# Altium schematic sheet 3.INPUT_GENSchDoc.SchDoc
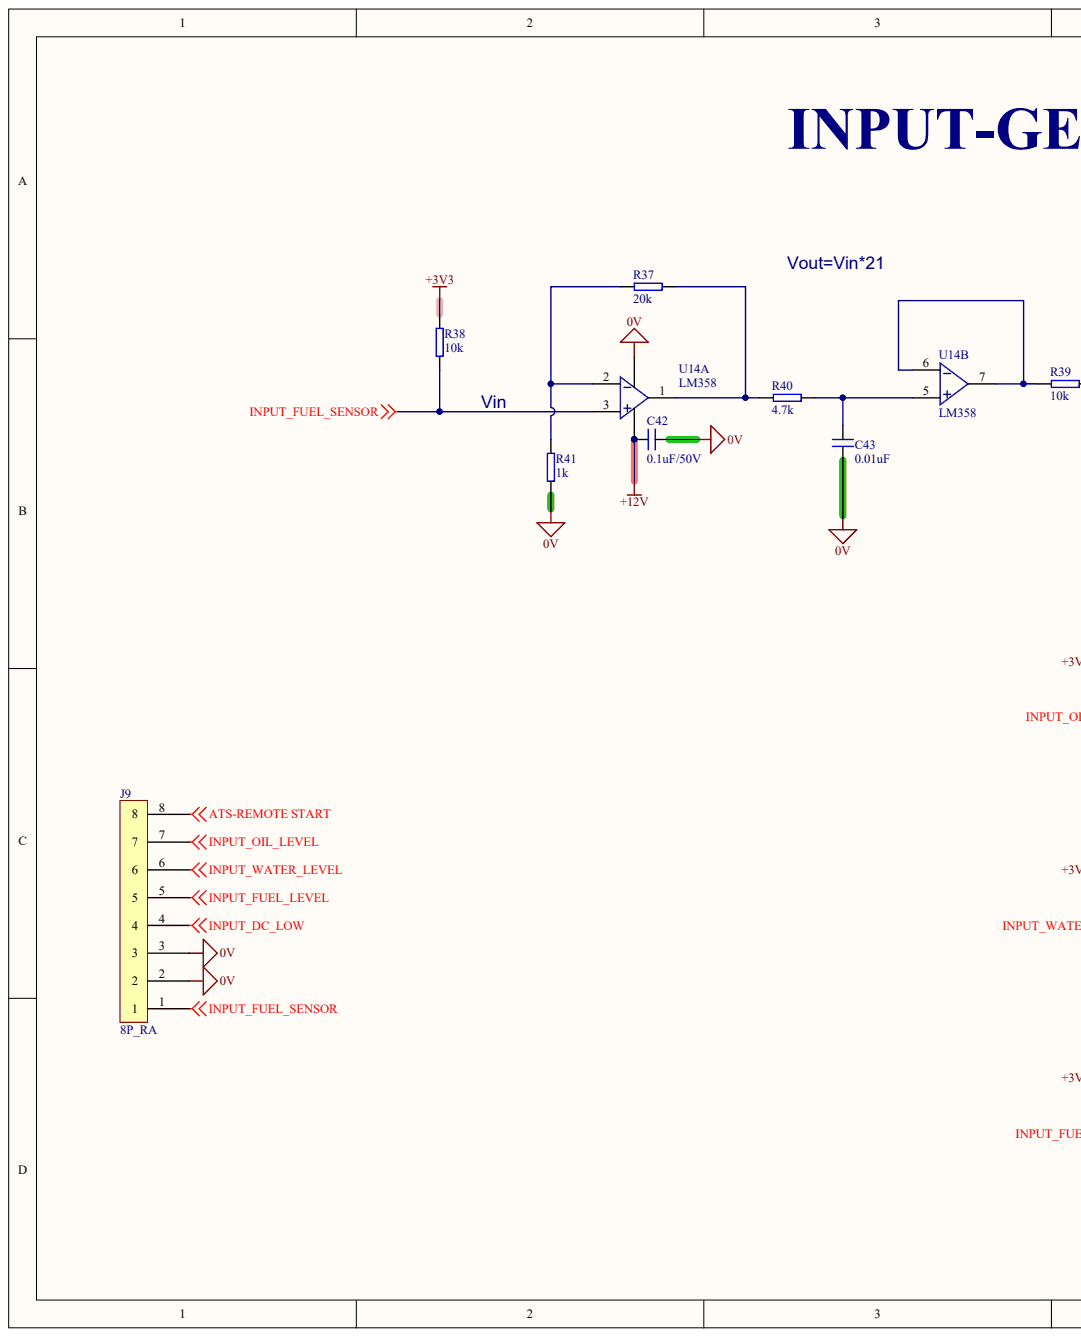
[diagram p0: 3.INPUT_GENSchDoc.SchDoc, left]
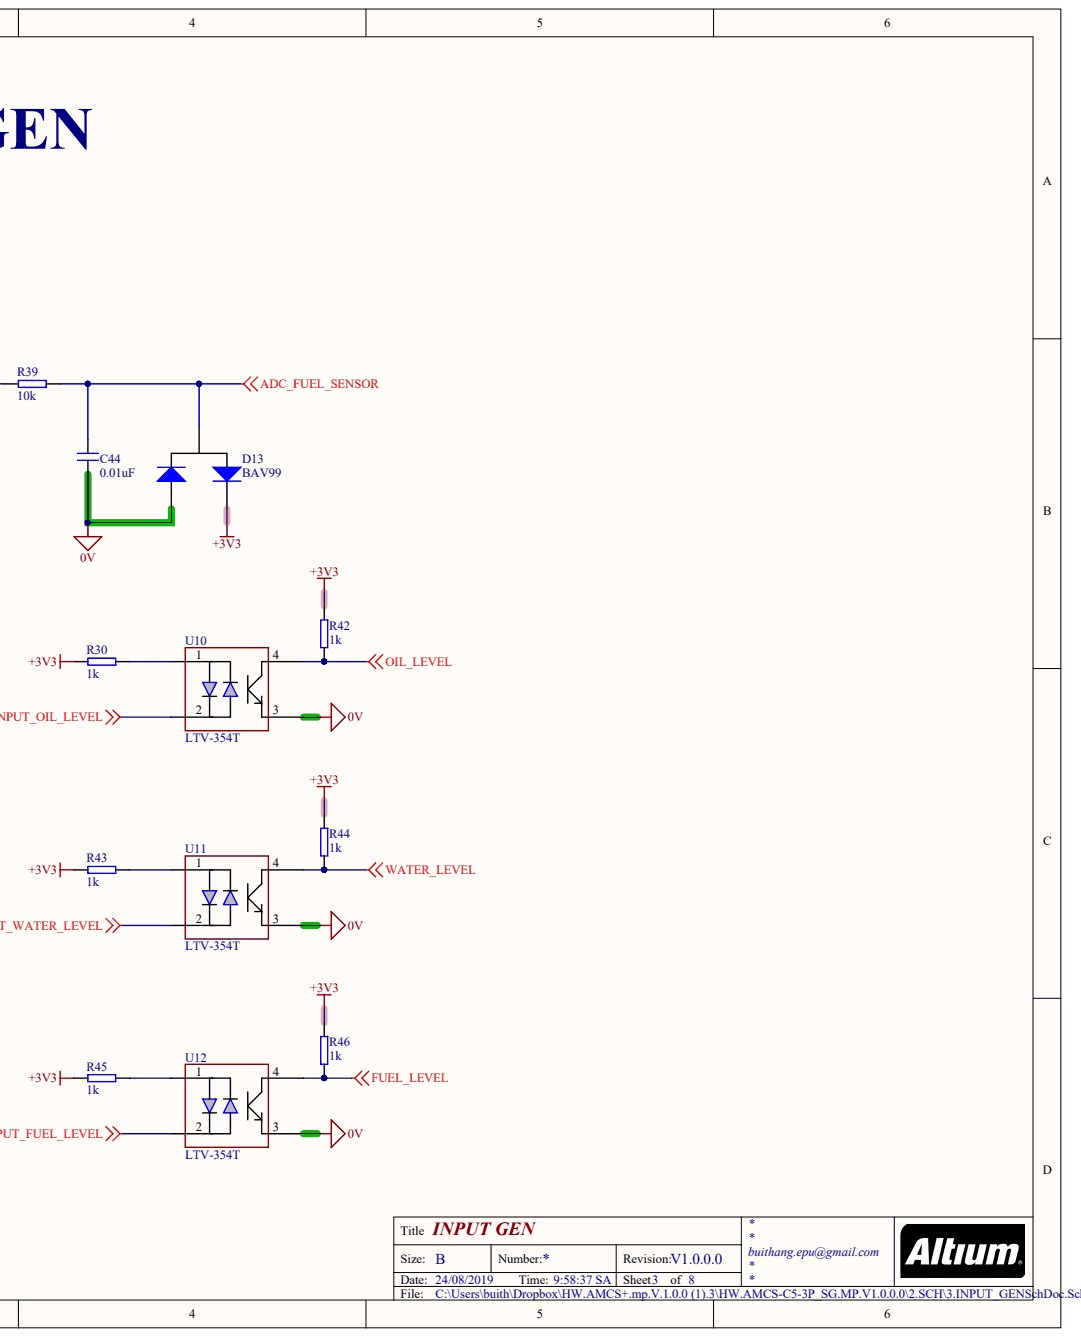
[diagram p1: 3.INPUT_GENSchDoc.SchDoc, right]

## Parts
C42  0.1uF/50V  [0805]
C43  0.01uF  [0805]
C44  0.01uF  [0805]
D13  BAV99  [SOT-23]
J9  8P_RA  [CONN_8x1_3.81MM]
R30  1k  [0805]
R37  20k  [0805]
R38  10k  [0805]
R39  10k  [0805]
R40  4.7k  [0805]
R41  1k  [0805]
R42  1k  [0805]
R43  1k  [0805]
R44  1k  [0805]
R45  1k  [0805]
R46  1k  [0805]
U10  LTV-354T  [SMD-4]
U11  LTV-354T  [SMD-4]
U12  LTV-354T  [SMD-4]
U14  LM358  [SOIC-8_3.9]  (parts A,B)

## Nets
0V: C42.1 C43.1 C44.1 D13.1 J9.2 J9.3 R41.2 U10.3 U11.3 U12.3 U14.4
+3V3: D13.2 R30.2 R38.1 R42.1 R43.2 R44.1 R45.2 R46.1
+12V: C42.2 U14.8
ADC_FUEL_SENSOR: C44.2 D13.3 R39.2
ATS-REMOTE START: J9.8
FUEL_LEVEL: R46.2 U12.4
INPUT_DC_LOW: J9.4
INPUT_FUEL_LEVEL: J9.5 U12.2
INPUT_FUEL_SENSOR: J9.1 R38.2 U14.3
INPUT_OIL_LEVEL: J9.7 U10.2
INPUT_WATER_LEVEL: J9.6 U11.2
OIL_LEVEL: R42.2 U10.4
WATER_LEVEL: R44.2 U11.4
NetC43_2: C43.2 R40.1 U14.5
NetR30_1: R30.1 U10.1
NetR37_1: R37.1 R41.1 U14.2
NetR37_2: R37.2 R40.2 U14.1
NetR39_1: R39.1 U14.6 U14.7
NetR43_1: R43.1 U11.1
NetR45_1: R45.1 U12.1

## Library data of the parts
C42 = Cap_0.1uF: CAP CER 0.1UF 50V Y5V 0805; MFR: Yageo; Part Number: CC0805ZRY5V9BB104; AMCS CODE: VT-CAP-0004; ASS: SMT; Component Kind: Standard; Package: 0805; Library Name: Schlib_Diepvn_V01.SchLib
C43 C44 = Cap_0.01uF: CAP CER 10nF 50V X7R 0805; MFR: Yageo; Part Number: CC0805KRX7R9BB103; AMCS CODE: VT-CAP-0007; Component Kind: Standard; Package: 0805; Library Name: Schlib_Diepvn_V01.SchLib
D13 = DiO BAV99: DIODE ARRAY GP 100V 215MA SOT23; MFR: NXP Semiconductors; Part Number: BAV99,215; AMCS CODE: VT-DIO-0001; Component Kind: Standard; Package: SOT23; Library Name: Schlib_Diepvn_V01.SchLib
J9 = CONN_8x1_3.81: Pitch: 3.81MM, 8 POS 90 DEG; MFR: TE; Part Number: 1-284513-0; Part Number 1: 284513-8; AMCS CODE: VT-CON-0022; ASS: TH; Component Kind: Standard; Package: Pitch: 3.81; TYPE: CONN; Library Name: Schlib_Diepvn_V01.SchLib
R30 R43 R45 = Res_1k_0805: RES 1K OHM 1/10W 1% 0603 SMD; MFR: Yageo; Part Number: RC0805FR-071KL; AMCS CODE: VT-RES-0003; ASS: SMT; Component Kind: Standard; Package: 0805; TYPE: RES; Library Name: Schlib_Diepvn_V01.SchLib
R37 = Res_20k: RES SMD 20K OHM 1% 1/10W 0603; MFR: Panasonic Electronic Components; Part Number: ERJ-3EKF2002V; Component Kind: Standard; Package: 0603; Library Name: Schlib_Diepvn_V01.SchLib
R38 R39 = Res_10k: RES SMD 10K OHM 1% 1/8W 0805; MFR: Yageo; Part Number: RC0805FR-0710KL; AMCS CODE: VT-RES-0001; ASS: SMT; Component Kind: Standard; Package: 0805; Library Name: Schlib_Diepvn_V01.SchLib
R40 = Res_4.7k_0805: RES SMD 4.7K OHM 1% 1/8W 0805; MFR: Yageo; Part Number: RC0805FR-074K7L; AMCS CODE: VT-RES-0004; ASS: SMT; Component Kind: Standard; Package: 0805; TYPE: RES; Library Name: Schlib_Diepvn_V01.SchLib
R41 R42 R44 R46 = Res_1k_0805: RES SMD 1K OHM 1% 1/8W 0805; MFR: Yageo; Part Number: RC0805FR-071KL; Vender PartNumber: 311-1.00KCRTR-ND; AMCS CODE: VT-RES-0003; ASS: SMT; Detailed Description: 1 kOhms ±1% 0.125W, 1/8W Chip Resistor 0805 (2012 Metric) Moisture Resistant Thick Film; Power (Watts): 0.125W, 1/8W; Resistance: 1 kOhms; Temperature Coefficient: ±100ppm/°C; Vender: Digi-Key; Component Kind: Standard; Operating Temperature: -55°C ~ 155°C; Package: 0805 (2012 Metric); Size / Dimension: 0.079" L x 0.049" W (2.00mm x 1.25mm); Tolerance: ±1%; TYPE: RES; Library Name: Schlib_Diepvn_V01.SchLib
U10-U12 = AC_OPTO: Optoisolator Transistor Output 3750Vrms 1 Channel 4-SOP (2.54mm); MFR: Lite-ON.Inc; AMCS CODE: VT-IC-0001; Component Kind: Standard; Package: 4-SOP (2.54mm); Part Number: LTV-354T; Library Name: Schlib_Diepvn_V01.SchLib
U14 = LM2903: IC OPAMP GP 1.1MHZ 8SO; MFR: STMicroelectronics; Part Number: LM358DT; Detailed Description: General Purpose Amplifier 2 Circuit 8-SO; Component Kind: Standard; Digi-Key Part Number: 497-1591-2-ND; Package / Case: 8-SOIC (0.154", 3.90mm Width); Library Name: Schlib_Diepvn_V01.SchLib
